# Altium schematic sheet Drivers_Matrices.SchDoc
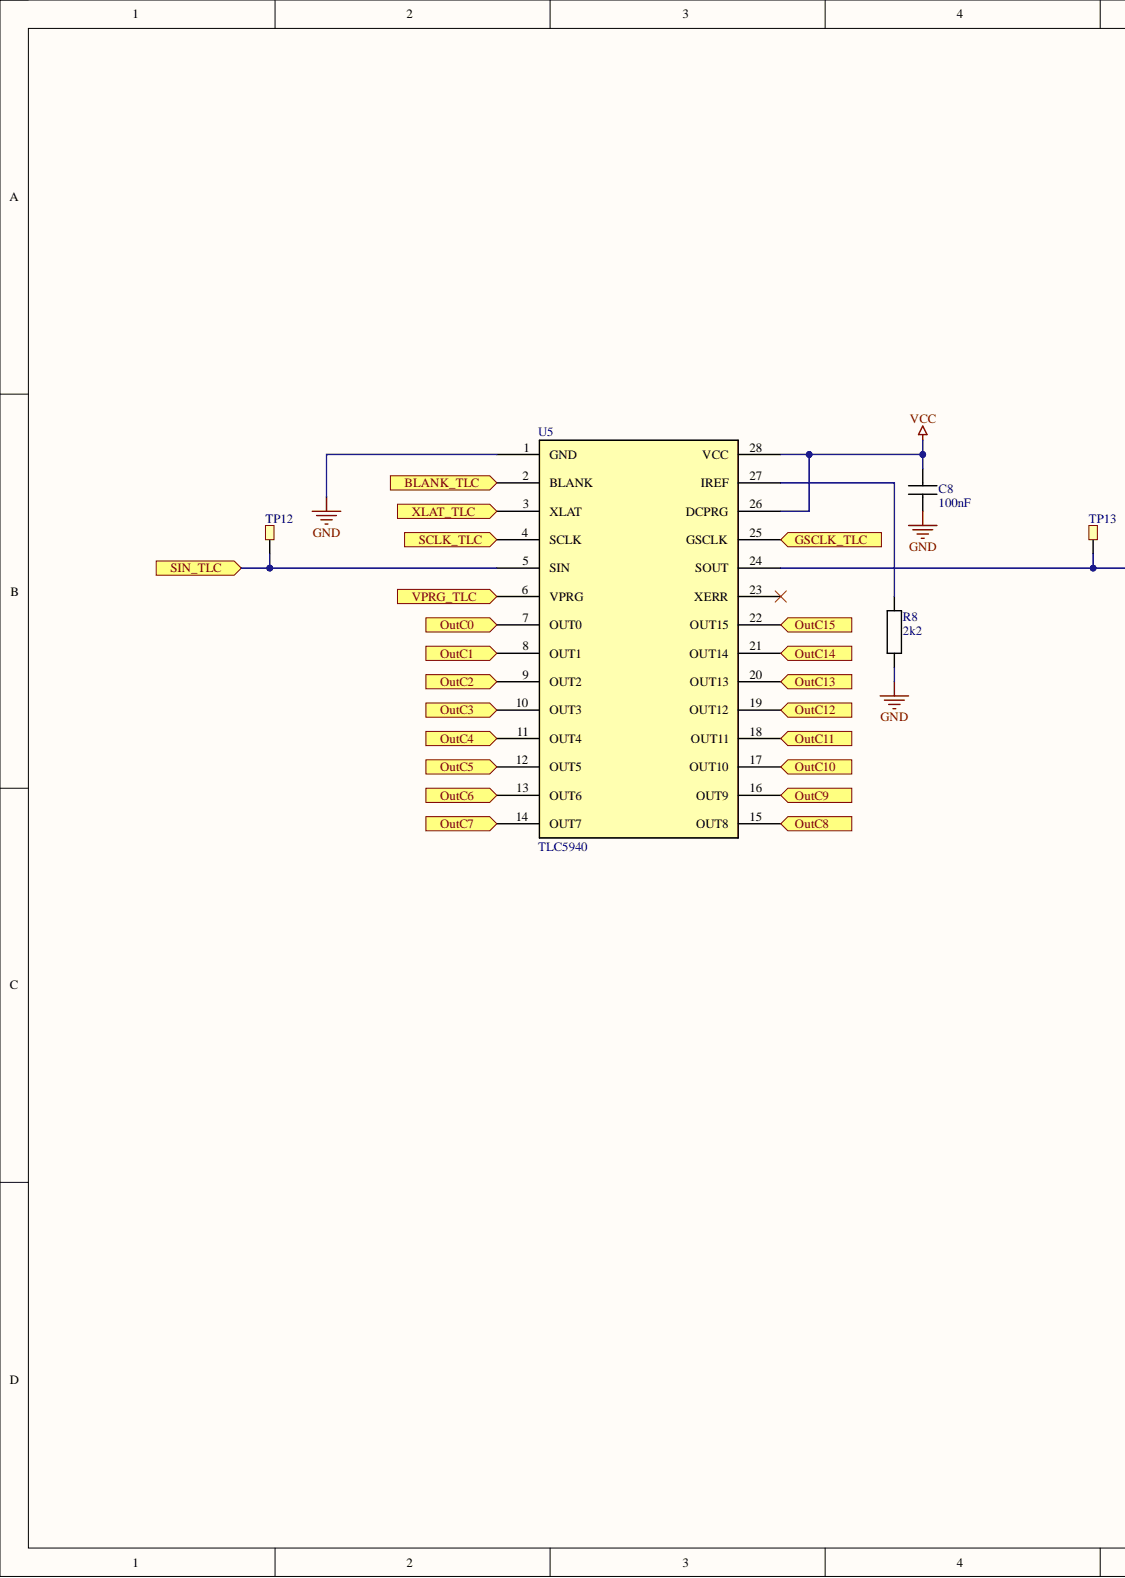
[diagram p0: Drivers_Matrices.SchDoc, left]
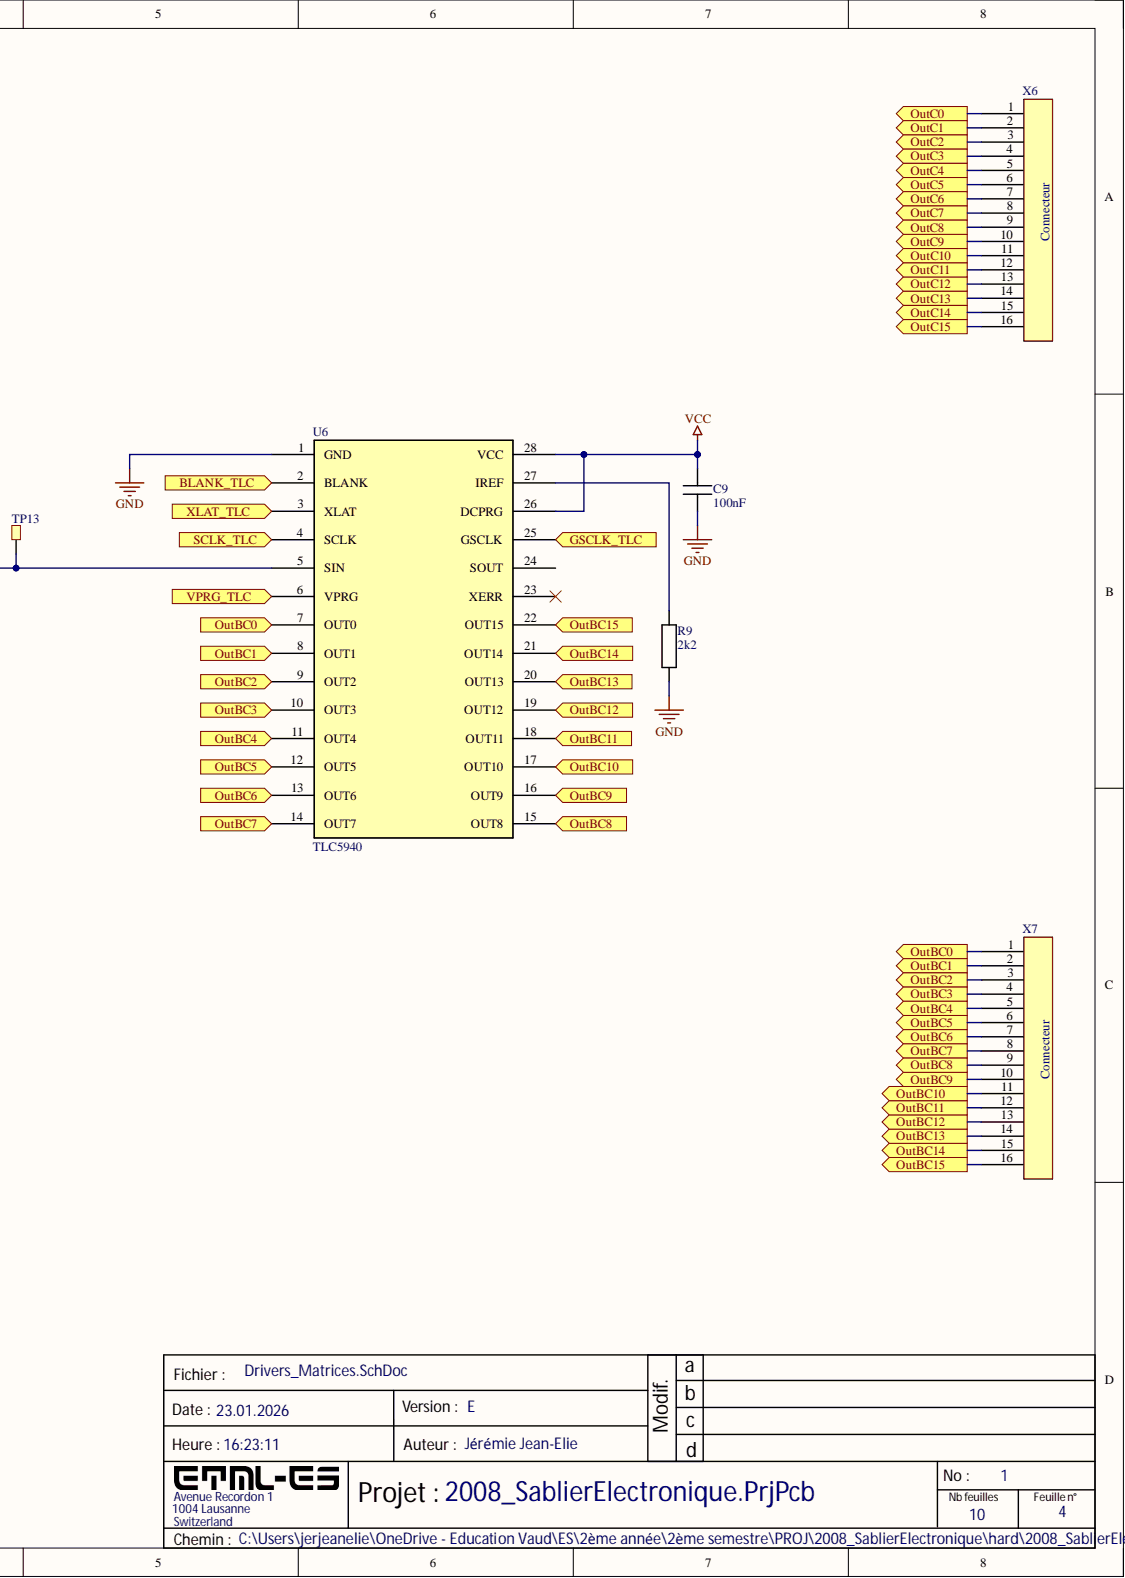
[diagram p1: Drivers_Matrices.SchDoc, right]

## Parts
C8  100nF  [CAPC1608X08M]
C9  100nF  [CAPC1608X08M]
R8  2k2  [RESC1608X06M]
R9  2k2  [RESC1608X06M]
TP12  5019  [TESTPOINT_SMD]  (value not drawn)
TP13  5019  [TESTPOINT_SMD]  (value not drawn)
U5  TLC5940
U6  TLC5940
X6  (no value)  [CON8x8(edited)]
X7  (no value)  [CON8x8(edited)]

## Ports
BLANK_TLC, GSCLK_TLC, OutBC0, OutBC1, OutBC2, OutBC3, OutBC4, OutBC5, OutBC6, OutBC7, OutBC8, OutBC9, OutBC10, OutBC11, OutBC12, OutBC13, OutBC14, OutBC15, OutC0, OutC1, OutC2, OutC3, OutC4, OutC5, OutC6, OutC7, OutC8, OutC9, OutC10, OutC11, OutC12, OutC13, OutC14, OutC15, SCLK_TLC, SIN_TLC, VPRG_TLC, XLAT_TLC

## Nets
GND: C8.1 C9.1 R8.1 R9.1 U5.1(GND) U6.1(GND)
VCC: C8.2 C9.2 U5.26(DCPRG) U5.28(VCC) U6.26(DCPRG) U6.28(VCC)
BLANK_TLC: U5.2(BLANK) U6.2(BLANK) ; port BLANK_TLC
GSCLK_TLC: U5.25(GSCLK) U6.25(GSCLK) ; port GSCLK_TLC
OutBC0: U6.7(OUT0) X7.1 ; port OutBC0
OutBC1: U6.8(OUT1) X7.2 ; port OutBC1
OutBC2: U6.9(OUT2) X7.3 ; port OutBC2
OutBC3: U6.10(OUT3) X7.4 ; port OutBC3
OutBC4: U6.11(OUT4) X7.5 ; port OutBC4
OutBC5: U6.12(OUT5) X7.6 ; port OutBC5
OutBC6: U6.13(OUT6) X7.7 ; port OutBC6
OutBC7: U6.14(OUT7) X7.8 ; port OutBC7
OutBC8: U6.15(OUT8) X7.9 ; port OutBC8
OutBC9: U6.16(OUT9) X7.10 ; port OutBC9
OutBC10: U6.17(OUT10) X7.11 ; port OutBC10
OutBC11: U6.18(OUT11) X7.12 ; port OutBC11
OutBC12: U6.19(OUT12) X7.13 ; port OutBC12
OutBC13: U6.20(OUT13) X7.14 ; port OutBC13
OutBC14: U6.21(OUT14) X7.15 ; port OutBC14
OutBC15: U6.22(OUT15) X7.16 ; port OutBC15
OutC0: U5.7(OUT0) X6.1 ; port OutC0
OutC1: U5.8(OUT1) X6.2 ; port OutC1
OutC2: U5.9(OUT2) X6.3 ; port OutC2
OutC3: U5.10(OUT3) X6.4 ; port OutC3
OutC4: U5.11(OUT4) X6.5 ; port OutC4
OutC5: U5.12(OUT5) X6.6 ; port OutC5
OutC6: U5.13(OUT6) X6.7 ; port OutC6
OutC7: U5.14(OUT7) X6.8 ; port OutC7
OutC8: U5.15(OUT8) X6.9 ; port OutC8
OutC9: U5.16(OUT9) X6.10 ; port OutC9
OutC10: U5.17(OUT10) X6.11 ; port OutC10
OutC11: U5.18(OUT11) X6.12 ; port OutC11
OutC12: U5.19(OUT12) X6.13 ; port OutC12
OutC13: U5.20(OUT13) X6.14 ; port OutC13
OutC14: U5.21(OUT14) X6.15 ; port OutC14
OutC15: U5.22(OUT15) X6.16 ; port OutC15
SCLK_TLC: U5.4(SCLK) U6.4(SCLK) ; port SCLK_TLC
SIN_TLC: TP12.1 U5.5(SIN) ; port SIN_TLC
VPRG_TLC: U5.6(VPRG) U6.6(VPRG) ; port VPRG_TLC
XLAT_TLC: U5.3(XLAT) U6.3(XLAT) ; port XLAT_TLC
NetR8_2: R8.2 U5.27(IREF)
NetR9_2: R9.2 U6.27(IREF)
NetTP13_1: TP13.1 U5.24(SOUT) U6.5(SIN)

Not connected: U5 23(XERR); U6 23(XERR) 24(SOUT)

## Library data of the parts
C8 C9 = CMP-065-000000-2: CAPC 100nF X7R 50V 10% 1608 (0603 INCH); Manufacturer: TDK; Manufacturer Number: CGA3E2X7R1H104K080AA; Current: NULL; IsStocked: 1; PN: PN-338083; TemperatureRange: -55°C to +125°C; Category: Passives; Component Kind: Standard; Dielectric: X7R; Library Name: ETML-ES; Power: NULL; SubCategory: Condensateurs ceramique; Supplier 1: ETML; Supplier Part Number 1: FAB.0603_C 100n; Supplier Price 1: 0.0100; TemperatureCoeff: NULL; Tolerance: ±10%; Value: 100nF; Voltage: 50V
R8 R9 = CMP-066-000116-2: RES 2.2kohm  ±1% 0.1W  1608 (0603 INCH); Manufacturer: Yageo; Manufacturer Number: RC0603FR-072K2L; IsStocked: 1; PN: PN-844453; TemperatureCoeff: ±100ppm/°C; TemperatureRange: -55°C to 155°C; Value: 2.2k; Voltage: 75V; Category: Passives; Component Kind: Standard; Library Name: ETML-ES; Power: 0.1W; SubCategory: Resistances couche epaisse; Supplier 1: ETML; Supplier Part Number 1: FAB.0603_R 2k2; Supplier Price 1: 0.0200; Tolerance: ±1%
TP12 TP13 = TESTPOINT: Test point smd; Component Kind: Standard; Supplier 1: Mouser; Supplier Part Number 1: 534-5019; Library Name: 2008_SablierElectronique_SCHLIB.SchLib
X6 X7 = Mâle DIN 8 x 8: Component Kind: Standard; Library Name: 2008_SablierElectronique_SCHLIB.SchLib
U5 U6 = TLC5940: Component Kind: Standard; Library Name: Schlib1.SchLib
